# Altium schematic sheet C9842-D170036-0_1.SchDoc
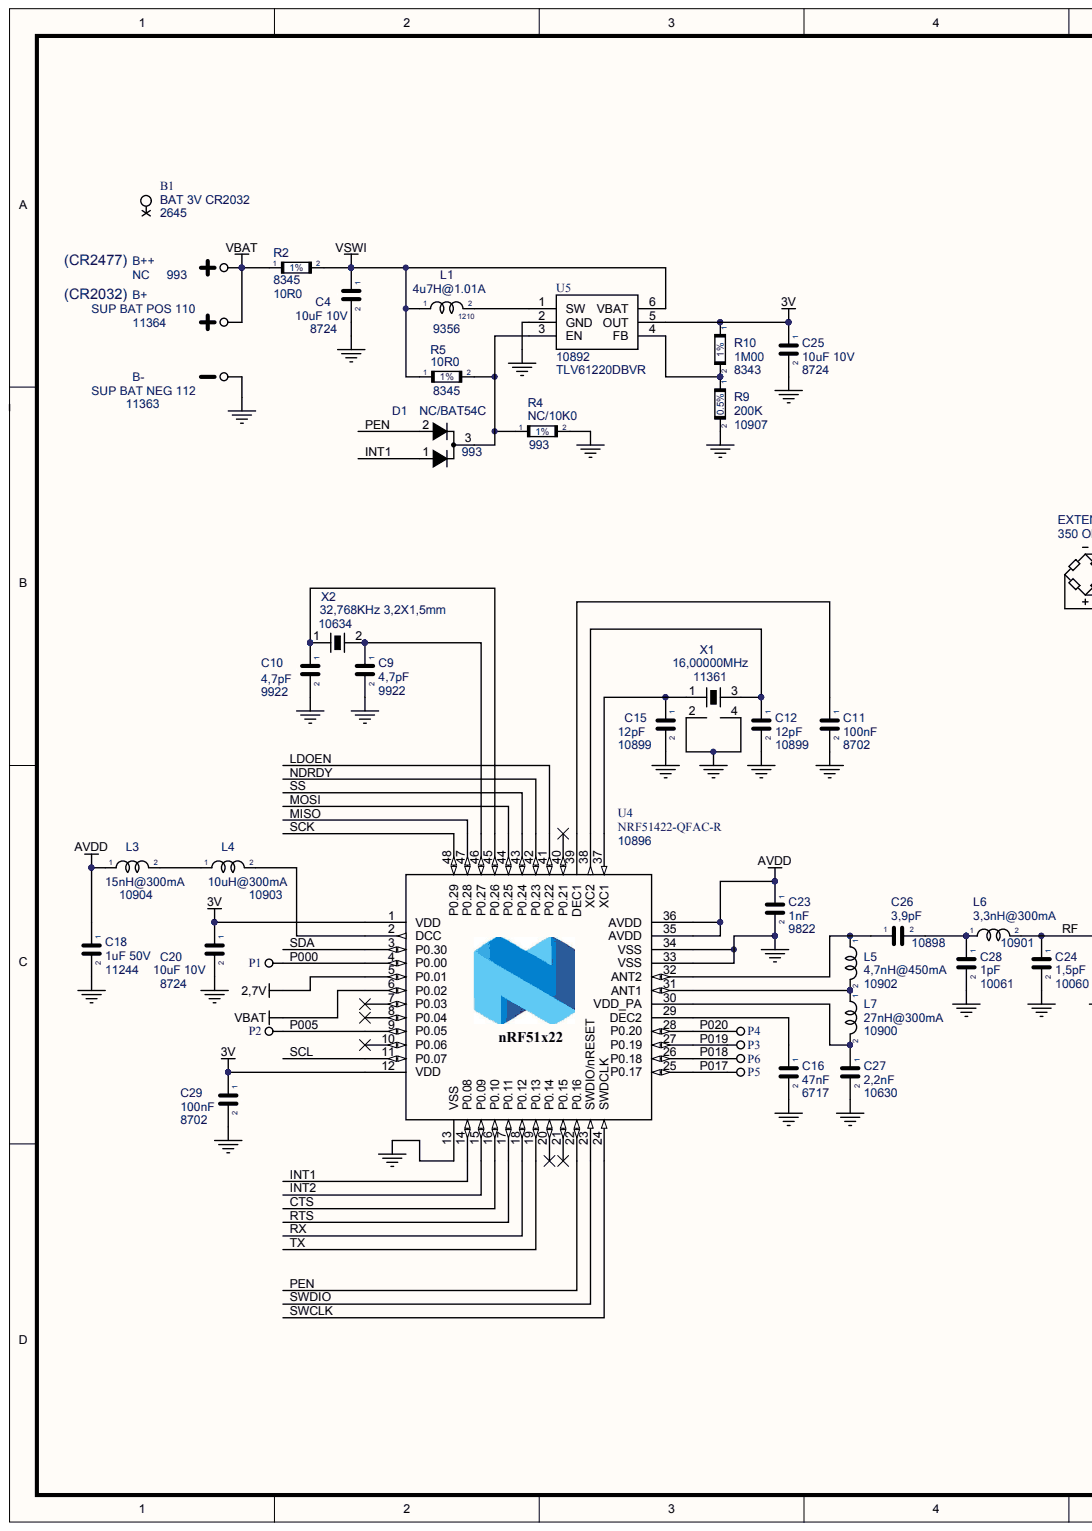
[diagram p0: C9842-D170036-0_1.SchDoc, left]
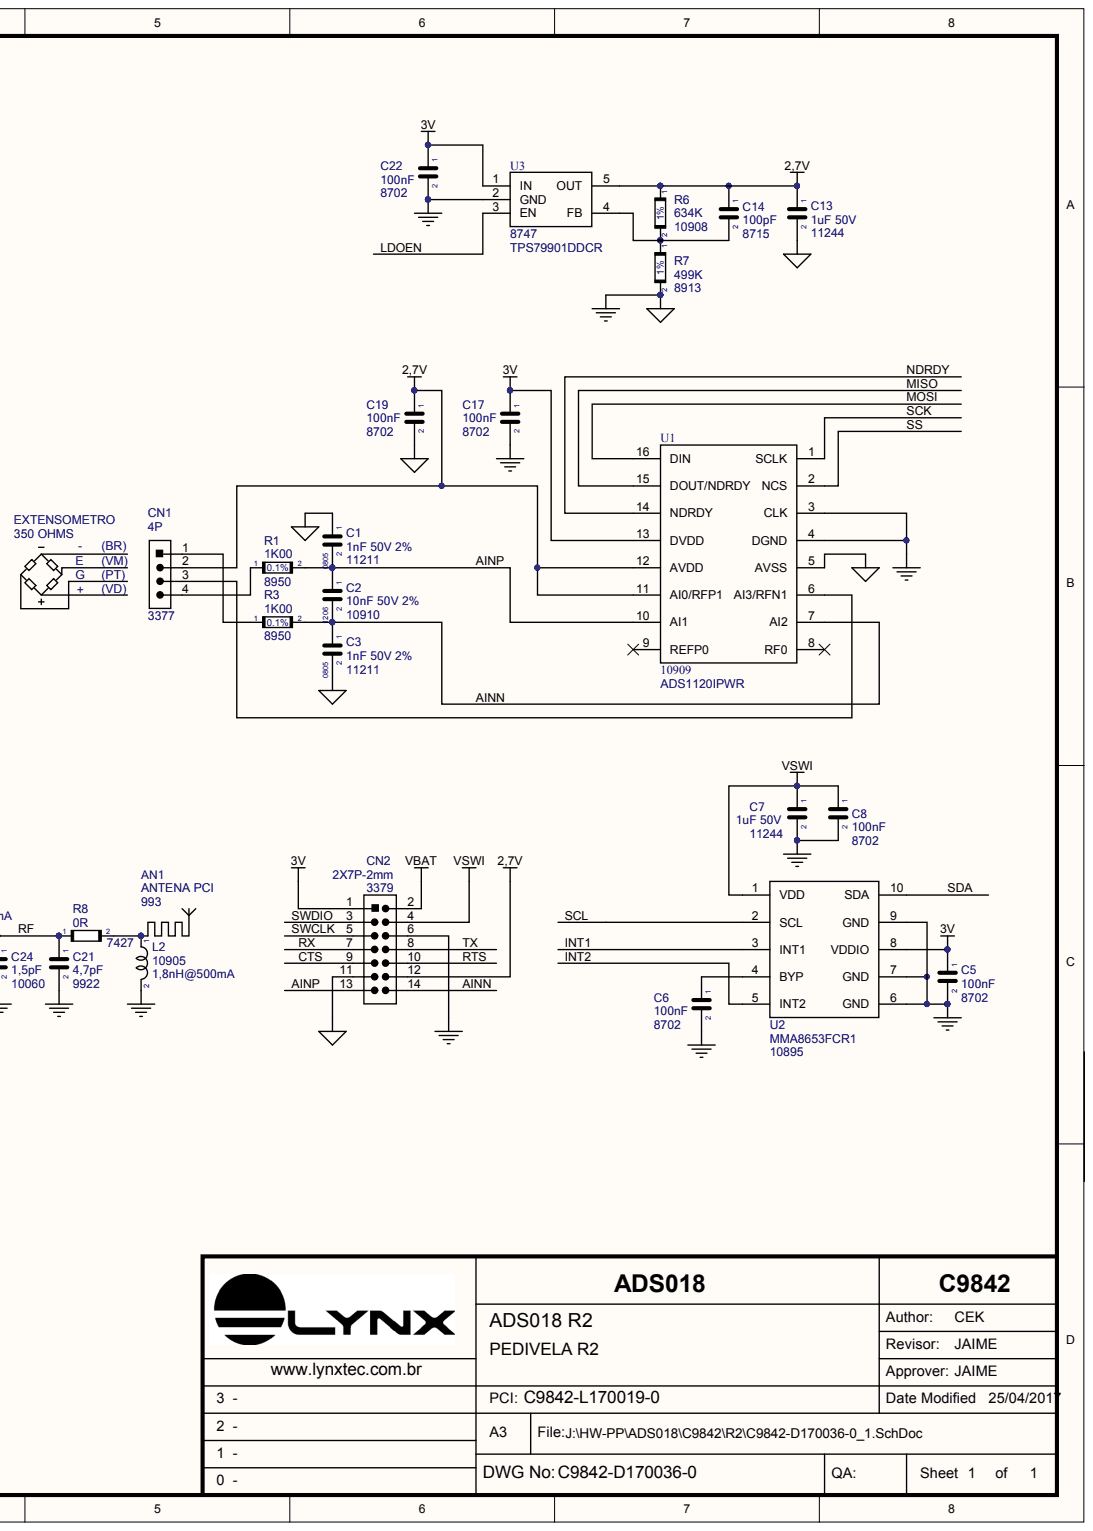
[diagram p1: C9842-D170036-0_1.SchDoc, right]

## Parts
AN1  Antena PCI
B1  BAT 3V CR2032  LYNX: 2645
B+  value SUP BAT POS 110  LYNX: 11364  (hidden comment: TERMINAL POSITIVO BAT CR2032/CR2477)
B++  value NC  (hidden comment: TERMINAL POSITIVO BAT CR2032/CR2477)
B-
C1  value 1nF 50V 2%  LYNX: 11211  (hidden comment: 1nF 0805 PPS)
C2  value 10nF 50V 2%  LYNX: 10910  (hidden comment: 10nF 1206 PPS)
C3  value 1nF 50V 2%  LYNX: 11211  (hidden comment: 1nF 0805 PPS)
C4  value 10uF 10V  LYNX: 8724  (hidden comment: 10uF 0603)
C5  value 100nF  LYNX: 8702  (hidden comment: 100nF 0603)
C6  value 100nF  LYNX: 8702  (hidden comment: 100nF 0603)
C7  value 1uF 50V  LYNX: 11244  (hidden comment: 1uF 50V 0603)
C8  value 100nF  LYNX: 8702  (hidden comment: 100nF 0603)
C9  value 4,7pF  LYNX: 9922  (hidden comment: 4,7pF 0603)
C10  value 4,7pF  LYNX: 9922  (hidden comment: 4,7pF 0603)
C11  value 100nF  LYNX: 8702  (hidden comment: 100nF 0603)
C12  value 12pF  LYNX: 10899  (hidden comment: 12pF 0603)
C13  value 1uF 50V  LYNX: 11244  (hidden comment: 1uF 50V 0603)
C14  value 100pF  LYNX: 8715  (hidden comment: 100pF 0603)
C15  value 12pF  LYNX: 10899  (hidden comment: 12pF 0603)
C16  value 47nF  LYNX: 6717  (hidden comment: 47nF 0603)
C17  value 100nF  LYNX: 8702  (hidden comment: 100nF 0603)
C18  value 1uF 50V  LYNX: 11244  (hidden comment: 1uF 50V 0603)
C19  value 100nF  LYNX: 8702  (hidden comment: 100nF 0603)
C20  value 10uF 10V  LYNX: 8724  (hidden comment: 10uF 0603)
C21  value 4,7pF  LYNX: 9922  (hidden comment: 4,7pF 0603)
C22  value 100nF  LYNX: 8702  (hidden comment: 100nF 0603)
C23  value 1nF  LYNX: 9822  (hidden comment: 1nF 0603)
C24  value 1,5pF  LYNX: 10060  (hidden comment: 1,5pF 0603)
C25  value 10uF 10V  LYNX: 8724  (hidden comment: 10uF 0603)
C26  value 3,9pF  LYNX: 10898  (hidden comment: 3,9pF 0603)
C27  value 2,2nF  LYNX: 10630  (hidden comment: 2,2nF 0603 100V 5%)
C28  value 1pF  LYNX: 10061  (hidden comment: 1pF 0603)
C29  value 100nF  LYNX: 8702  (hidden comment: 100nF 0603)
CN1  value 4P  LYNX: 3377  (hidden comment: JUMPER 4P)
CN2  value 2X7P-2mm  LYNX: 3379  (hidden comment: HEADER2X7)
D1  value NC/BAT54C  (hidden comment: DIODO SMD BAT54C)
L1  value 4u7H@1.01A  LYNX: 9356  (hidden comment: INDUTOR SMD 1210 4u7H@1.01A)
L2  value 1,8nH@500mA  LYNX: 10905  (hidden comment: INDUTOR SMD 0603 1,8nH@500mA)
L3  value 15nH@300mA  LYNX: 10904  (hidden comment: INDUTOR SMD 0603 15nH@300mA)
L4  value 10uH@300mA  LYNX: 10903  (hidden comment: INDUTOR SMD 0603 10uH@300mA)
L5  value 4,7nH@450mA  LYNX: 10902  (hidden comment: INDUTOR SMD 0603 4,7nH@450mA)
L6  value 3,3nH@300mA  LYNX: 10901  (hidden comment: INDUTOR SMD 0603 3,3nH@300mA)
L7  value 27nH@300mA  LYNX: 10900  (hidden comment: INDUTOR SMD 0603 27nH@300mA)
P1  PONTO DE TESTE NC  (value not drawn)
P2  PONTO DE TESTE NC  (value not drawn)
P3  PONTO DE TESTE NC  (value not drawn)
P4  PONTO DE TESTE NC  (value not drawn)
P5  PONTO DE TESTE NC  (value not drawn)
P6  PONTO DE TESTE NC  (value not drawn)
R1  value 1K00  LYNX: 8950  (hidden comment: R SMD 1K00 0,1% 0603)
R2  value 10R0  LYNX: 8345  (hidden comment: R SMD 10R0 1% 0603)
R3  value 1K00  LYNX: 8950  (hidden comment: R SMD 1K00 0,1% 0603)
R4  value NC/10K0  (hidden comment: R SMD 10K0 1% 0603)
R5  value 10R0  LYNX: 8345  (hidden comment: R SMD 10R0 1% 0603)
R6  value 634K  LYNX: 10908  (hidden comment: R SMD 634K 1% 0603)
R7  value 499K  LYNX: 8913  (hidden comment: R SMD 499K 1% 0603)
R8  value 0R  LYNX: 7427  (hidden comment: R SMD 0R 5% 0603)
R9  value 200K  LYNX: 10907  (hidden comment: R SMD 200K 0,5% 0603)
R10  value 1M00  LYNX: 8343  (hidden comment: R SMD 1M00 1% 0603)
U1  ADS1120IPWR  LYNX: 10909
U2  value MMA8653FCR1  LYNX: 10895  (hidden comment: MMA8653FCR1 10-DFN)
U3  value TPS79901DDCR  LYNX: 8747  (hidden comment: REGULADOR TPS79901DDCR SOT23-5)
U4  LYNX: 10896  (hidden comment: NRF51422-QFAC-R)
U5  value TLV61220DBVR  LYNX: 10892  (hidden comment: TLV61220DBVR SOT23-6)
X1  value 16,00000MHz  LYNX: 11361  (hidden comment: SMD 16,00000MHz 2,0X1,6x0,4mm-12pF)
X2  value 32,768KHz 3,2X1,5mm  LYNX: 10634  (hidden comment: SMD 32,76800KHz-3,2X1,5mm 6pF)

## Nets
2,7V: C13.1 C14.1 C19.1 CN1.2 CN2.12 R6.1 U1.11(AI0/RFP1) U1.12(AVDD) U3.5(OUT) U4.5(P0.01)
3V: C5.1 C17.1 C20.1 C22.1 C25.1 C29.1 CN2.1 R10.1 U1.13(DVDD) U2.8(VDDIO) U3.1(IN) U4.1(VDD) U4.12(VDD) U5.5(OUT)
AGND: B-.1 C1.1 C3.2 C4.2 C5.2 C6.2 C7.2 C8.2 C9.2 C10.2 C11.2 C12.2 C13.2 C15.2 C16.2 C17.2 C18.2 C19.2 C20.2 C21.2 C22.2 C23.2 C24.2 C25.2 C27.2 C28.2 C29.2 CN2.6 CN2.11 L2.2 R4.2 R7.2 R9.2 U1.3(CLK) U1.4(DGND) U1.5(AVSS) U2.6(GND) U2.7(GND) U2.9(GND) U3.2(GND) U4.13(VSS) U4.33(VSS) U4.34(VSS) U4.49 U5.2(GND) X1.2 X1.4
AVDD: C18.1 C23.1 L3.1 U4.35(AVDD) U4.36(AVDD)
NetB1: B1.
VBAT: B+.1 B++.1 CN2.2 R2.1 U4.6(P0.02)
VSWI: C4.1 C7.1 C8.1 CN2.4 L1.1 R2.2 R5.1 U2.1(VDD) U5.6(VBAT)
AINN: C2.2 C3.1 CN2.14 R3.2 U1.7(AI2)
AINP: C1.2 C2.1 CN2.13 R1.2 U1.10(AI1)
CTS: CN2.9 U4.16(P0.10)
INT1: D1.1 U2.3(INT1) U4.14(P0.08)
INT2: U2.5(INT2) U4.15(P0.09)
LDOEN: U3.3(EN) U4.41(P0.22)
MISO: U1.15(DOUT/NDRDY) U4.47(P0.28)
MOSI: U1.16(DIN) U4.44(P0.25)
NDRDY: U1.14(NDRDY) U4.42(P0.23)
P000: P1.1 U4.4(P0.00)
P005: P2.1 U4.9(P0.05)
P017: P5.1 U4.25(P0.17)
P018: P6.1 U4.26(P0.18)
P019: P3.1 U4.27(P0.19)
P020: P4.1 U4.28(P0.20)
PEN: D1.2 U4.22(P0.16)
RF: C21.1 C24.1 L6.2 R8.1
RTS: CN2.10 U4.17(P0.11)
RX: CN2.7 U4.18(P0.12)
SCK: U1.1(SCLK) U4.48(P0.29)
SCL: U2.2(SCL) U4.11(P0.07)
SDA: U2.10(SDA) U4.3(P0.30)
SS: U1.2(NCS) U4.43(P0.24)
SWCLK: CN2.5 U4.24(SWDCLK)
SWDIO: CN2.3 U4.23(SWDIO/nRESET)
TX: CN2.8 U4.19(P0.13)
NetAN1_1: AN1.1 L2.1 R8.2
NetC6_1: C6.1 U2.4(BYP)
NetC9_1: C9.1 U4.46(P0.27) X2.2
NetC10_1: C10.1 U4.45(P0.26) X2.1
NetC11_1: C11.1 U4.39(DEC1)
NetC12_1: C12.1 U4.38(XC2) X1.3
NetC14_2: C14.2 R6.2 R7.1 U3.4(FB)
NetC15_1: C15.1 U4.37(XC1) X1.1
NetC16_1: C16.1 U4.29(DEC2)
NetC26_1: C26.1 L5.1 U4.32(ANT2)
NetC26_2: C26.2 C28.1 L6.1
NetC27_1: C27.1 L7.2 U4.30(VDD_PA)
NetCN1_1: CN1.1 R3.1
NetCN1_3: CN1.3 U1.6(AI3/RFN1)
NetCN1_4: CN1.4 R1.1
NetD1_3: D1.3 R4.1 R5.2 U5.3(EN)
NetL1_2: L1.2 U5.1(SW)
NetL3_2: L3.2 L4.1
NetL4_2: L4.2 U4.2(DCC)
NetL5_2: L5.2 L7.1 U4.31(ANT1)
NetR9_1: R9.1 R10.2 U5.4(FB)
N00013:
N00014:

Not connected: U1 8(RF0) 9(REFP0); U4 7(P0.03) 8(P0.04) 10(P0.06) 20(P0.14) 21(P0.15) 40(P0.21)

## Library data of the parts
AN1 = (no symbol name): PCP: NC; LYNX: 993; VALUE: ANTENA PCI
B1 = (no symbol name): PCP: BAT-LITIO-3V-MOD-CR2032; VALUE: BAT 3V CR2032
B+ = (no symbol name): PCP: SUPORTE-BAT-POS-110TR
B++ P1-P6 = (no symbol name): LYNX: 993; PCP: NC
  P1-P6: VALUE: NC
C1 C3 = (no symbol name): PCP: C-SMD-0805-PPS-2%-50V-1nF
C2 = (no symbol name): PCP: C-SMD-1206-PPS-2%-50V-10nF
C4 C20 C25 = (no symbol name): PCP: C-SMD-0603-X5R-20%-10V-10uF
C5 C6 C8 C11 C17 C19 C22 C29 = (no symbol name): PCP: C-SMD-0603-X7R-10%-50V-100nF
C7 C13 C18 = (no symbol name): PCP: C-SMD-0603-X7R-10%-50V-1uF
C9 C10 C21 = (no symbol name): PCP: C-SMD-0603-NPO-5%-50V-4,7pF
C12 C15 = (no symbol name): PCP: C-SMD-0603-NPO-1%-50V-12pF
C14 = (no symbol name): PCP: C-SMD-0603-NPO-1%-100V-100pF
C16 = (no symbol name): PCP: C-SMD-0603-X7R-10%-50V-47nF
C23 = (no symbol name): PCP: C-SMD-0603-NPO-5%-50V-1nF
C24 = (no symbol name): PCP: C-SMD-0603-NPO-5%-50V-1,5pF
C26 = (no symbol name): PCP: C-SMD-0603-NPO-5%-50V-3,9pF
C27 = (no symbol name): PCP: C-SMD-0603-NPO-5%-100V-2,2nF
C28 = (no symbol name): PCP: C-SMD-0603-NPO-5%-50V-1pF
CN1 = (no symbol name): PCP: BR-MSO11F40; LatestRevisionDate: 17-Jul-2002; LatestRevisionNote: Re-released for DXP Platform.; Publisher: Altium Limited
CN2 = (no symbol name): PCP: BR-MSO21F20-2mm
D1 = (no symbol name): PCP: D-SMD-K-BAT54C; LYNX: 993
L1 = (no symbol name): PCP: L-SMD-1210-4u7H@1.01A
L2 = (no symbol name): PCP: L-SMD-0603-1,8nH@500mA
L3 = (no symbol name): PCP: L-SMD-0603-15nH@300mA
L4 = (no symbol name): PCP: L-SMD-0603-10uH@300mA
L5 = (no symbol name): PCP: L-SMD-0603-4,7nH@450mA
L6 = (no symbol name): PCP: L-SMD-0603-3,3nH@300mA
L7 = (no symbol name): PCP: L-SMD-0603-27nH@300mA
R1 R3 = (no symbol name): PCP: R-SMD-0603-0,1%-1/10W-1K00
R2 R5 = (no symbol name): PCP: R-SMD-0603-1%-1/16W-10R
R4 = (no symbol name): PCP: R-SMD-0603-1%-1/16W-10K; LYNX: 993
R6 = (no symbol name): PCP: R-SMD-0603-1%-1/10W-634K
R7 = (no symbol name): PCP: R-SMD-0603-1%-1/10W-499K
R8 = (no symbol name): PCP: R-SMD-0603-5%-1/16W-0R
R9 = (no symbol name): PCP: R-SMD-0603-0,5%-1/16W-T25-200K
R10 = (no symbol name): PCP: R-SMD-0603-1%-1/16W-1M00
U1 = (no symbol name): PCP: CI-SMD-ADS1120IPWR; VALUE: ADS1120IPWR
U2 = (no symbol name): PCP: CI-SMD-MMA8653FCR1
U3 = (no symbol name): PCP: CI-SMD-TPS79901DDCR
U4 = (no symbol name): Part Number: nRF51x22-QFAC; Manufacturer: Nordic Semiconductor; Note: nRF51422 - QFN48 6x6 0.4mm pitch; Artikkelnr Noca: N.A.; PCP: CI-SMD-NRF51422-QFAC-R; Manufacturer Part Number: nRF51x22-QFAC; Datasheet: *; LatestRevisionDate: 2014-09-24; Pin Count: 48; Published: 2014-09-24; Supplier: Nordic Semiconductor; Supplier part number: *; 2nd source Supplier: *; 2nd source part number: *; Footprint Doc: QFN-48; Artikkelnr Simpro: N.A.; Value: NRF51422-QFAC-R; Publisher: Nordic Semiconductor
U5 = (no symbol name): PCP: CI-SMD-TLV61220DBVR
X1 = (no symbol name): PCP: X-SMD-16,00000MHz-2,0X1,6x0,4mm-12pF
X2 = (no symbol name): PCP: X-SMD-32,76800KHz-3,2X1,5mm-6pF
